# Altium schematic sheet DVT-PSU-LM5116-HT_1.SchDoc(DVT-PSU-LM5116-HT_1)
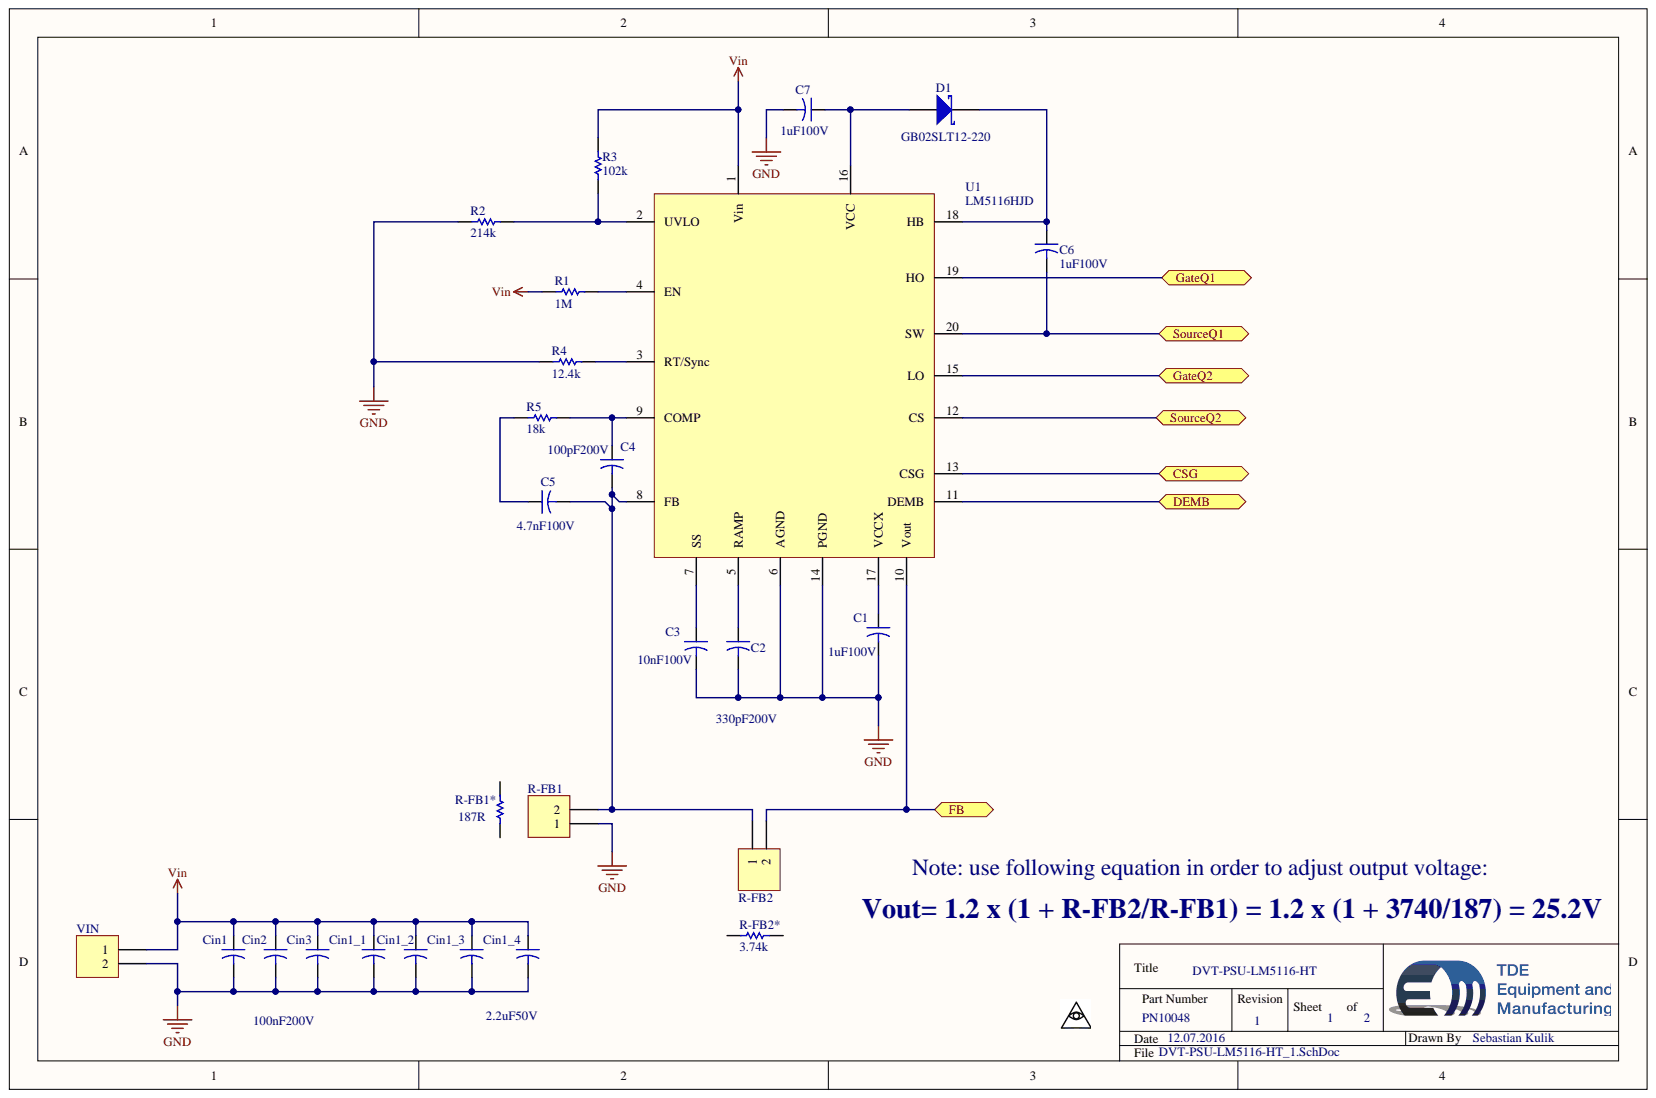
[diagram p0: DVT-PSU-LM5116-HT_1.SchDoc]

## Parts
*  Eye  [Eye]  (value not drawn)
C1  value 1uF100V  [Dummy-10mm]  (hidden comment: Capacitor-Dummy-THT)
C2  value 330pF200V  [Dummy-10mm]  (hidden comment: Capacitor-Dummy-THT)
C3  value 10nF100V  [Dummy-10mm]  (hidden comment: Capacitor-Dummy-THT)
C4  value 100pF200V  [Dummy-10mm]  (hidden comment: Capacitor-Dummy-THT)
C5  value 4.7nF100V  [Dummy-10mm]  (hidden comment: Capacitor-Dummy-THT)
C6  value 1uF100V  [Dummy-10mm]  (hidden comment: Capacitor-Dummy-THT)
C7  value 1uF100V  [Dummy-10mm]  (hidden comment: Capacitor-Dummy-THT)
Cin1  Capacitor-Dummy-THT  [Dummy-10mm]  (value not drawn)
Cin1_1  Capacitor-Dummy-THT  [Dummy-10mm]  (value not drawn)
Cin1_2  Capacitor-Dummy-THT  [Dummy-10mm]  (value not drawn)
Cin1_3  value 2.2uF50V  [Dummy-10mm]  (hidden comment: Capacitor-Dummy-THT)
Cin1_4  value 2.2uF50V  [Dummy-10mm]  (hidden comment: Capacitor-Dummy-THT)
Cin2  value 100nF200V  [Dummy-10mm]  (hidden comment: Capacitor-Dummy-THT)
Cin3  value 100nF200V  [Dummy-10mm]  (hidden comment: Capacitor-Dummy-THT)
D1  GB02SLT12-220  [TO220AC]
R1  value 1M  [Dummy-10mm]  (hidden comment: Resistor-Dummy-THT)
R2  value 214k  [Dummy-10mm]  (hidden comment: Resistor-Dummy-THT)
R3  value 102k  [Dummy-10mm]  (hidden comment: Resistor-Dummy-THT)
R4  value 12.4k  [Dummy-10mm]  (hidden comment: Resistor-Dummy-THT)
R5  value 18k  [Dummy-10mm]  (hidden comment: Resistor-Dummy-THT)
R-FB1  PHOENIX_1715721  [Phoenix-2-5_08]  (value not drawn)
R-FB1*  value 187R  (hidden comment: Resistor-Dummy)
R-FB2  PHOENIX_1715721  [Phoenix-2-5_08]  (value not drawn)
R-FB2*  value 3.74k  (hidden comment: Resistor-Dummy)
U1  LM5116HJD  [DIP-20-Ceramic-HT]
VIN  PHOENIX_1715721  [Phoenix-2-5_08]  (value not drawn)

## Ports
CSG, DEMB, FB, GATEQ1, GATEQ2, SOURCEQ1, SOURCEQ2

## Nets
GND: C1.2 C2.2 C3.2 C7.2 Cin1.2 Cin1_1.2 Cin1_2.2 Cin1_3.2 Cin1_4.2 Cin2.2 Cin3.2 R2.1 R4.1 R-FB1.1 U1.6(AGND) U1.14(PGND) VIN.2
VIN: Cin1.1 Cin1_1.1 Cin1_2.1 Cin1_3.1 Cin1_4.1 Cin2.1 Cin3.1 R1.1 R3.2 U1.1(Vin) VIN.1
CSG: U1.13(CSG) ; port CSG
DEMB: U1.11(DEMB) ; port DEMB
FB: R-FB2.2 U1.10(Vout) ; port FB
NetC1_1: C1.1 U1.17(VCCX)
NetC2_1: C2.1 U1.5(RAMP)
NetC3_1: C3.1 U1.7(SS)
NetC4_1: C4.1 R5.2 U1.9(COMP)
NetC4_2: C4.2 C5.2 R-FB1.2 R-FB2.1 U1.8(FB)
NetC5_1: C5.1 R5.1
NetC6_1: C6.1 D1.1 U1.18(HB)
NetC6_2: C6.2 U1.20(SW) ; port SOURCEQ1
NetC7_1: C7.1 D1.2 U1.16(VCC)
NetR1_2: R1.2 U1.4(EN)
NetR2_2: R2.2 R3.1 U1.2(UVLO)
NetR4_2: R4.2 U1.3(RT/Sync)
NetU1_12: U1.12(CS) ; port SOURCEQ2
NetU1_15: U1.15(LO) ; port GATEQ2
NetU1_19: U1.19(HO) ; port GATEQ1

Not connected: R-FB1* 1 2; R-FB2* 1 2

## Library data of the parts
C1 C6 C7 = Capacitor-Dummy-THT: Deliverer PartNr: 1672256; Deliverer: Farnell; Component Kind: Standard; Description: Capacitor-Dummy-THT; Library Name: Capacitors.IntLib; Manufacturer: -; Manufacturer PartNr: -; PackageDescription: -; Part Description: Capacitor-Dummy-THT; Price: 5.25/1; Published: 08.12.2015; Signal Integrity: Cap; Simulation: CAP; TDE-PN: -; Publisher: Sebastian Kulik
C2 = Capacitor-Dummy-THT: Deliverer PartNr: 2452200; Deliverer: Farnell; Component Kind: Standard; Description: Capacitor-Dummy-THT; Library Name: Capacitors.IntLib; Manufacturer: -; Manufacturer PartNr: -; PackageDescription: -; Part Description: Capacitor-Dummy-THT; Price: 6.27/1; Published: 08.12.2015; Signal Integrity: Cap; Simulation: CAP; TDE-PN: -; Publisher: Sebastian Kulik
C3 = Capacitor-Dummy-THT: Deliverer PartNr: 2452194; Deliverer: Farnell; Component Kind: Standard; Description: Capacitor-Dummy-THT; Library Name: Capacitors.IntLib; Manufacturer: -; Manufacturer PartNr: -; PackageDescription: -; Part Description: Capacitor-Dummy-THT; Price: 9.84/1; Published: 08.12.2015; Signal Integrity: Cap; Simulation: CAP; TDE-PN: -; Publisher: Sebastian Kulik
C4 = Capacitor-Dummy-THT: Deliverer PartNr: 2452201; Deliverer: Farnell; Component Kind: Standard; Description: Capacitor-Dummy-THT; Library Name: Capacitors.IntLib; Manufacturer: -; Manufacturer PartNr: -; PackageDescription: -; Part Description: Capacitor-Dummy-THT; Price: 6.23/1; Published: 08.12.2015; Signal Integrity: Cap; Simulation: CAP; TDE-PN: -; Publisher: Sebastian Kulik
C5 = Capacitor-Dummy-THT: Deliverer PartNr: 2452197; Deliverer: Farnell; Component Kind: Standard; Description: Capacitor-Dummy-THT; Library Name: Capacitors.IntLib; Manufacturer: -; Manufacturer PartNr: -; PackageDescription: -; Part Description: Capacitor-Dummy-THT; Price: 6.98/1; Published: 08.12.2015; Signal Integrity: Cap; Simulation: CAP; TDE-PN: -; Publisher: Sebastian Kulik
Cin1 = Capacitor-Dummy-THT: Deliverer PartNr: 2544877; Deliverer: Farnell; Value: 100nF200V; Component Kind: Standard; Description: Capacitor-Dummy-THT; Library Name: Capacitors.IntLib; Manufacturer: -; Manufacturer PartNr: -; PackageDescription: -; Part Description: Capacitor-Dummy-THT; Price: 0.51/10; Published: 08.12.2015; Signal Integrity: Cap; Simulation: CAP; TDE-PN: -; Publisher: Sebastian Kulik
Cin1_1 Cin1_2 = Capacitor-Dummy-THT: Deliverer PartNr: 2529490; Deliverer: Farnell; Value: 2.2uF50V; Component Kind: Standard; Description: Capacitor-Dummy-THT; Library Name: Capacitors.IntLib; Manufacturer: -; Manufacturer PartNr: -; PackageDescription: -; Part Description: Capacitor-Dummy-THT; Price: 1.28/1; Published: 08.12.2015; Signal Integrity: Cap; Simulation: CAP; TDE-PN: -; Publisher: Sebastian Kulik
Cin1_3 Cin1_4 = Capacitor-Dummy-THT: Deliverer PartNr: 2529490; Deliverer: Farnell; Component Kind: Standard; Description: Capacitor-Dummy-THT; Library Name: Capacitors.IntLib; Manufacturer: -; Manufacturer PartNr: -; PackageDescription: -; Part Description: Capacitor-Dummy-THT; Price: 1.28/1; Published: 08.12.2015; Signal Integrity: Cap; Simulation: CAP; TDE-PN: -; Publisher: Sebastian Kulik
Cin2 Cin3 = Capacitor-Dummy-THT: Deliverer PartNr: 2544877; Deliverer: Farnell; Component Kind: Standard; Description: Capacitor-Dummy-THT; Library Name: Capacitors.IntLib; Manufacturer: -; Manufacturer PartNr: -; PackageDescription: -; Part Description: Capacitor-Dummy-THT; Price: 0.51/10; Published: 08.12.2015; Signal Integrity: Cap; Simulation: CAP; TDE-PN: -; Publisher: Sebastian Kulik
D1 = GB02SLT12-220: Diode Shottky, 1200V, 2A; Deliverer PartNr: 1862679; Manufacturer: GeneSic; Deliverer: Farnell; TDE-PN: DIS0051; Component Kind: Standard; Library Name: Discrete.IntLib; Manufacturer PartNr: GB02SLT12-220; PackageDescription: TO220AC; Price: 4.26/1; Published: 11.07.2016; Simulation: 18TQ045; Publisher: Sebastian Kulik
R1 = Resistor-Dummy-THT: Deliverer PartNr: 149-228; Deliverer: RS; Component Kind: Standard; Description: Resistor-Dummy-THT; Library Name: Resistors.IntLib; Manufacturer: -; Manufacturer PartNr: -; PackageDescription: -; Part Desicription: Resistor-Dummy-THT; Price: 0.046/10; Published: 08.12.2015; Signal Integrity: Res; Simulation: RESISTOR; TDE-PN: -; Publisher: Sebastian Kulik
R2 = Resistor-Dummy-THT: Deliverer PartNr: 754-8827; Deliverer: RS; Component Kind: Standard; Description: Resistor-Dummy-THT; Library Name: Resistors.IntLib; Manufacturer: -; Manufacturer PartNr: -; PackageDescription: -; Part Desicription: Resistor-Dummy-THT; Price: 0.41/5; Published: 08.12.2015; Signal Integrity: Res; Simulation: RESISTOR; TDE-PN: -; Publisher: Sebastian Kulik
R3 = Resistor-Dummy-THT: Deliverer PartNr: 701-8036; Deliverer: RS; Component Kind: Standard; Description: Resistor-Dummy-THT; Library Name: Resistors.IntLib; Manufacturer: -; Manufacturer PartNr: -; PackageDescription: -; Part Desicription: Resistor-Dummy-THT; Price: 0.602/5; Published: 08.12.2015; Signal Integrity: Res; Simulation: RESISTOR; TDE-PN: -; Publisher: Sebastian Kulik
R4 = Resistor-Dummy-THT: Deliverer PartNr: 755-0833; Deliverer: RS; Component Kind: Standard; Description: Resistor-Dummy-THT; Library Name: Resistors.IntLib; Manufacturer: -; Manufacturer PartNr: -; PackageDescription: -; Part Desicription: Resistor-Dummy-THT; Price: 0.202/5; Published: 08.12.2015; Signal Integrity: Res; Simulation: RESISTOR; TDE-PN: -; Publisher: Sebastian Kulik
R5 = Resistor-Dummy-THT: Deliverer PartNr: 148-792; Deliverer: RS; Component Kind: Standard; Description: Resistor-Dummy-THT; Library Name: Resistors.IntLib; Manufacturer: -; Manufacturer PartNr: -; PackageDescription: -; Part Desicription: Resistor-Dummy-THT; Price: 0.157/10; Published: 08.12.2015; Signal Integrity: Res; Simulation: RESISTOR; TDE-PN: -; Publisher: Sebastian Kulik
R-FB1 R-FB2 VIN = 2Pol_1715721: Phoenix, 2 pol, 5.08mm, 22A, 715721; Deliverer PartNr: 193-0564; Deliverer: RS; TDE-PN: CON0008; Component Kind: Standard; LatestRevisionDate: 02.05.2014; LatestRevisionNote: Re-released for DXP Platform.; Library Name: Connectors.IntLib; Manufacturer: Phoenix; Manufacturer PartNr: 1715721; PackageDescription: Phoenix-2-5_08; Signal Integrity: Connector; Publisher: Sebastian Kulik
  R-FB1 R-FB2: Price: 0.976/5
R-FB1* = Resistor-Dummy: Deliverer PartNr: 701-7159; Part Desicription: Resistor-Dummy-THT; Deliverer: RS; Component Kind: Standard; Description: Resistor-Dummy; Library Name: Resistors.IntLib; Manufacturer: -; Manufacturer PartNr: -; PackageDescription: -; Price: 1.058/5; Published: 08.12.2015; Signal Integrity: Res; Simulation: RESISTOR; TDE-PN: -; Publisher: Sebastian Kulik
R-FB2* = Resistor-Dummy: Deliverer PartNr: 754-5709; Part Desicription: Resistor-Dummy-THT; Deliverer: RS; Component Kind: Standard; Description: Resistor-Dummy; Library Name: Resistors.IntLib; Manufacturer: -; Manufacturer PartNr: -; PackageDescription: -; Price: 0.37/5; Published: 08.12.2015; Signal Integrity: Res; Simulation: RESISTOR; TDE-PN: -; Publisher: Sebastian Kulik
U1 = LM5116HJD: Step-Down controller, wide range input, HT; Deliverer PartNr: 595-LM5116HJD; Manufacturer: TI; Deliverer: Mouser; TDE-PN: IC0074; Component Kind: Standard; Library Name: ICs.IntLib; Manufacturer PartNr: LM5116HJD; PackageDescription: DIP-20-Ceramic-HT; Price: 156.14/1; Published: 02.05.2016; Publisher: Sebastian Kulik
* = Eye: Component Kind: Standard; Library Name: ICs.IntLib
